# Altium schematic sheet Connectors.SchDoc(Connectors)
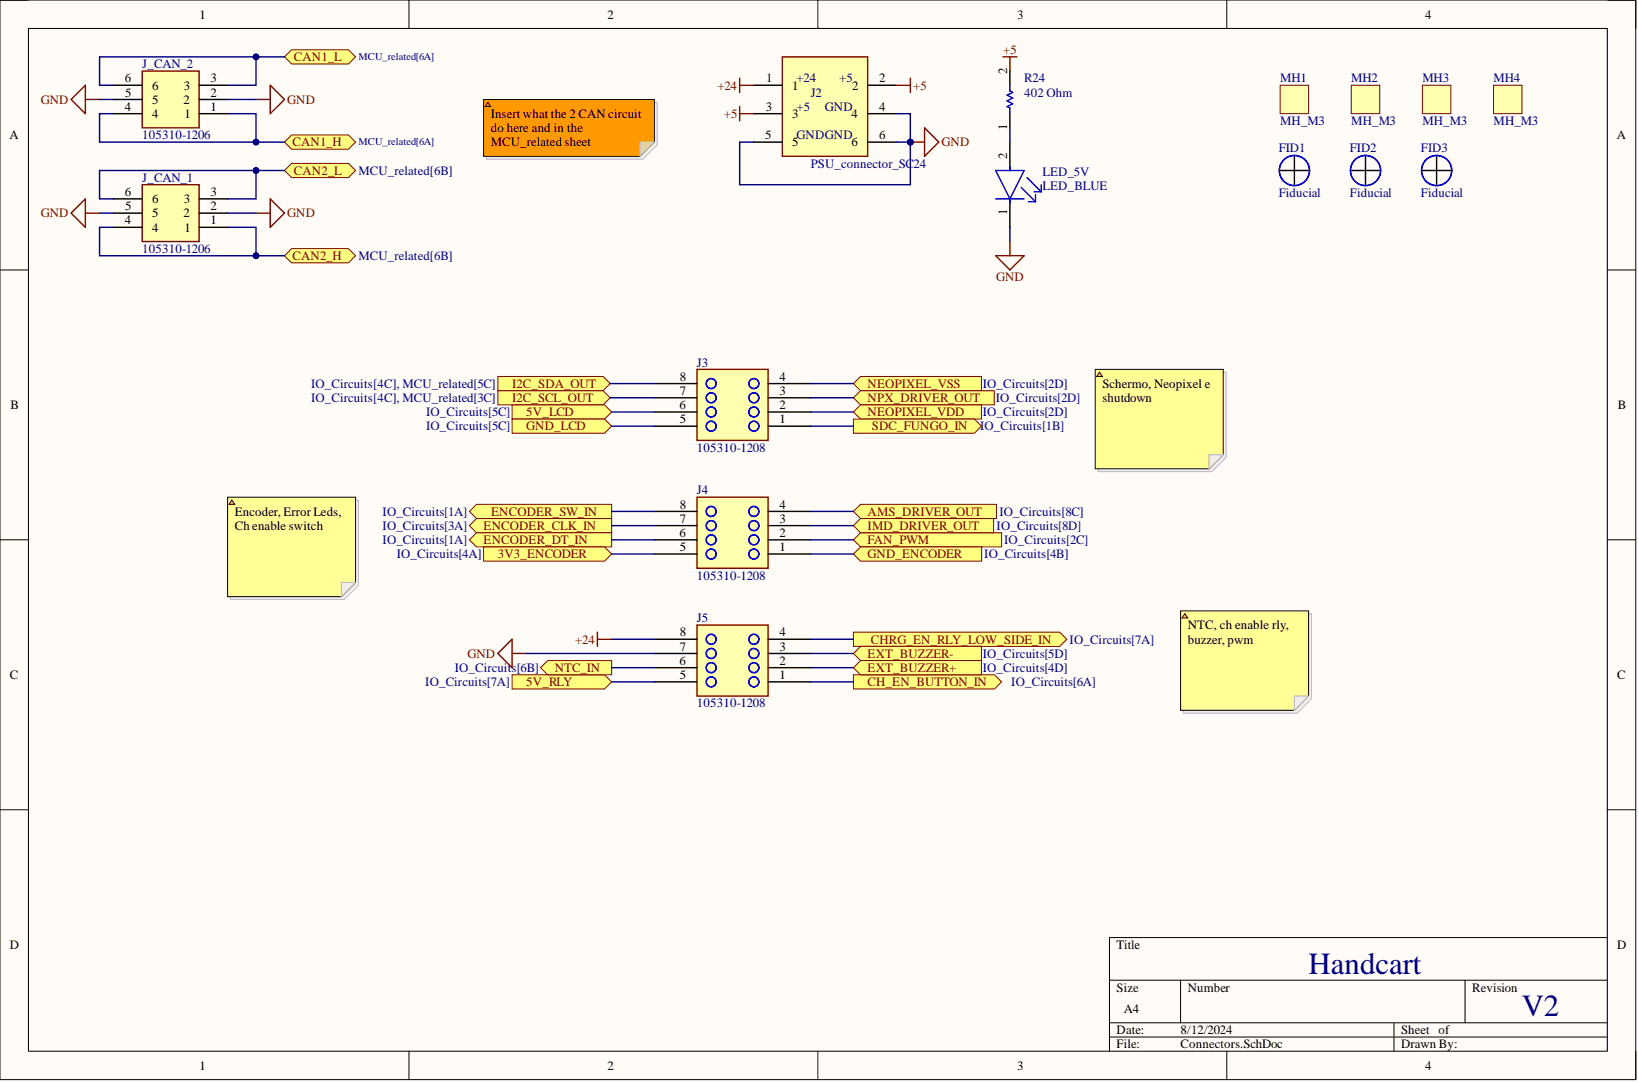
[diagram p0: Connectors.SchDoc]

## Parts
FID1  Fiducial
FID2  Fiducial
FID3  Fiducial
J2  PSU_connector_SC24
J3  105310-1208
J4  105310-1208
J5  105310-1208
J_CAN_1  105310-1206
J_CAN_2  105310-1206
LED_5V  LED_BLUE
MH1  MH_M3
MH2  MH_M3
MH3  MH_M3
MH4  MH_M3
R24  402 Ohm

## Ports
3V3_ENCODER, 5V_LCD, 5V_RLY, AMS_DRIVER_OUT, CAN1_H, CAN1_L, CAN2_H, CAN2_L, CH_EN_BUTTON_IN, CHRG_EN_RLY_LOW_SIDE_IN, ENCODER_CLK_IN, ENCODER_DT_IN, ENCODER_SW_IN, EXT_BUZZER+, EXT_BUZZER-, FAN_PWM, GND_ENCODER, GND_LCD, I2C_SCL_OUT, I2C_SDA_OUT, IMD_DRIVER_OUT, NEOPIXEL_VDD, NEOPIXEL_VSS, NPX_DRIVER_OUT, NTC_IN, SDC_FUNGO_IN

## Nets
+5: J2.2(+5_2) J2.3 R24.2
+24: J2.1 J5.8
GND: J2.4 J2.5 J2.6 J5.7 J_CAN_1.2 J_CAN_1.5 J_CAN_2.2 J_CAN_2.5 LED_5V.1
3V3_ENCODER: J4.5 ; port 3V3_ENCODER
5V_LCD: J3.6 ; port 5V_LCD
5V_RLY: J5.5 ; port 5V_RLY
AMS_DRIVER_OUT: J4.4 ; port AMS_DRIVER_OUT
CAN1_H: J_CAN_2.1 J_CAN_2.4 ; port CAN1_H
CAN1_L: J_CAN_2.3 J_CAN_2.6 ; port CAN1_L
CAN2_H: J_CAN_1.1 J_CAN_1.4 ; port CAN2_H
CAN2_L: J_CAN_1.3 J_CAN_1.6 ; port CAN2_L
CH_EN_BUTTON_IN: J5.1 ; port CH_EN_BUTTON_IN
CHRG_EN_RLY_LOW_SIDE_IN: J5.4 ; port CHRG_EN_RLY_LOW_SIDE_IN
ENCODER_CLK_IN: J4.7 ; port ENCODER_CLK_IN
ENCODER_DT_IN: J4.6 ; port ENCODER_DT_IN
ENCODER_SW_IN: J4.8 ; port ENCODER_SW_IN
FAN_PWM: J4.2 ; port FAN_PWM
GND_ENCODER: J4.1 ; port GND_ENCODER
GND_LCD: J3.5 ; port GND_LCD
I2C_SCL_OUT: J3.7 ; port I2C_SCL_OUT
I2C_SDA_OUT: J3.8 ; port I2C_SDA_OUT
IMD_DRIVER_OUT: J4.3 ; port IMD_DRIVER_OUT
NEOPIXEL_VDD: J3.2 ; port NEOPIXEL_VDD
NEOPIXEL_VSS: J3.4 ; port NEOPIXEL_VSS
NPX_DRIVER_OUT: J3.3 ; port NPX_DRIVER_OUT
NTC_IN: J5.6 ; port NTC_IN
SDC_FUNGO_IN: J3.1 ; port SDC_FUNGO_IN
NetJ5_2: J5.2
NetJ5_3: J5.3
NetLED_5V_2: LED_5V.2 R24.1

## Library data of the parts
FID1-FID3 = CMP-00028-00007-10: Component Kind: Standard; Library Name: EMEA- Squadra Corse PoliTO-  Politecnico di Torino
J2 = CMP-00019-4: 79107-7002; Component Kind: Standard; Library Name: EMEA- Squadra Corse PoliTO-  Politecnico di Torino
J3-J5 = CMP-00005-3: NanoFit 8 Pin, Vertical, Gold Plating; Component Kind: Standard; Library Name: EMEA- Squadra Corse PoliTO-  Politecnico di Torino
J_CAN_1 J_CAN_2 = 105310-1206: Connector; Manufacturer_Name: Molex; Component Kind: Standard; Datasheet Link: https://datasheet.datasheetarchive.com/originals/distributors/Datasheets_SAMA/56d7c21db279f41d468a63473e6110c8.pdf; Height: 10.71mm; Manufacturer_Part_Number: 105310-1206; Mouser Part Number: 538-105310-1206; Mouser Price/Stock: https://www.mouser.co.uk/ProductDetail/Molex/105310-1206?qs=PqFvFmEiOr5GOU0VddhB1g%3D%3D
LED_5V = CMP-007-00036-2: VLMB1300-GS08; Tech: SMD; Component Kind: Standard; Package: 0603; Library Name: EMEA- Squadra Corse PoliTO-  Politecnico di Torino
MH1-MH4 = CMP-00028-00008-3: Mountinh Hole M3, 3,5 mm hole, 6,5 mm external; Component Kind: Standard; Library Name: EMEA- Squadra Corse PoliTO-  Politecnico di Torino
R24 = CMP-009-00179-5: CRCW0603402RFKEA; Tech: SMD; Component Kind: Standard; ComponentLink1Description: Website; Package: 0603; Library Name: EMEA- Squadra Corse PoliTO-  Politecnico di Torino
